# Altium schematic sheet Power.SchDoc(Power)
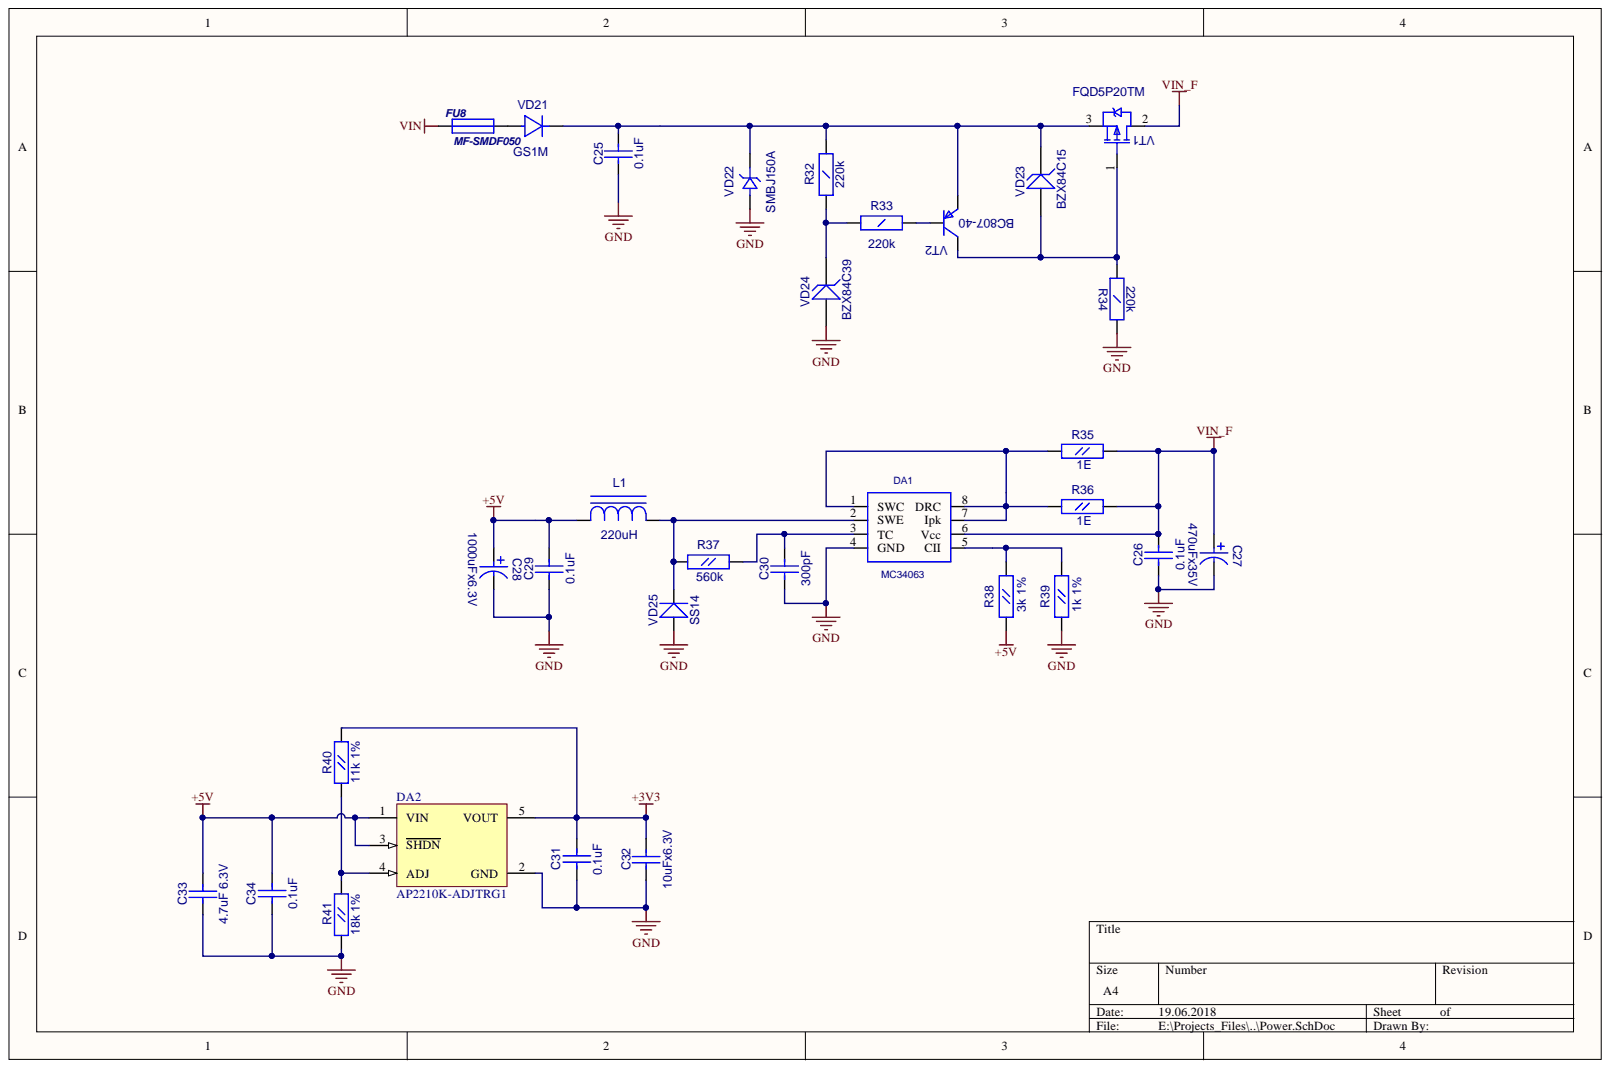
[diagram p0: Power.SchDoc]

## Parts
C25  0.1uF  [CC1206]
C26  0.1uF  [CC0603]
C27  470uFx35V  [CC16X10_CAP0.5(1)(Primary)]
C28  1000uFx6.3V  [CC8X3.5]
C29  0.1uF  [CC0603]
C30  300pF  [CC0603]
C31  0.1uF  [CC0603]
C32  10uFx6.3V  [CC0805]
C33  4.7uF 6.3V  [CC0805]
C34  0.1uF  [CC0603]
DA1  MC34063  [SO-8]
DA2  AP2210K-ADJTRG1  [SOT23-5]
FU8  MF-SMDF050  [MF-SMDF]
L1  220uH  [SDR0805]
R32  220k  [RC1206]
R33  220k  [RC1206]
R34  220k  [RC1206]
R35  1E  [RC0805]
R36  1E  [RC0805]
R37  560k  [RC0603]
R38  3k 1%  [RC0603]
R39  1k 1%  [RC0603]
R40  11k 1%  [RC0603]
R41  18k 1%  [RC0603]
VD21  GS1M  [SMA]
VD22  SMBJ150A  [SMB]
VD23  BZX84C15  [SOT-23(123)]
VD24  BZX84C39  [SOT-23(123)]
VD25  SS14  [SMA]
VT1  FQD5P20TM  [D-PAK(TO-252AA)]
VT2  BC807-40  [SOT-23(123)]

## Nets
+3V3: C31.1 C32.2 DA2.5(VOUT) R40.2
+5V: C28.1 C29.2 C33.2 C34.1 DA2.1(VIN) DA2.3(SHDN) L1.1 R38.1
GND: C25.1 C26.1 C27.1 C28.2 C29.1 C30.1 C31.2 C32.1 C33.1 C34.2 DA1.4(GND) DA2.2(GND) R34.2 R39.1 R41.1 VD22.1 VD24.1 VD25.1
VIN: FU8.1
VIN_F: C26.2 C27.2 DA1.6(Vcc) R35.2 R36.2 VT1.2
NetC25_2: C25.2 R32.2 VD21.2 VD22.2 VD23.3 VT1.3 VT2.2
NetC30_2: C30.2 DA1.3(TC) R37.2
NetDA1_1: DA1.1(SWC) DA1.7(Ipk) DA1.8(DRC) R35.1 R36.1
NetDA1_2: DA1.2(SWE) L1.2 R37.1 VD25.2
NetDA1_5: DA1.5(CII) R38.2 R39.2
NetDA2_4: DA2.4(ADJ) R40.1 R41.2
NetFU8_2: FU8.2 VD21.1
NetR32_1: R32.1 R33.1 VD24.3
NetR33_2: R33.2 VT2.1
NetR34_1: R34.1 VD23.1 VT1.1 VT2.3

## Library data of the parts
C25 = CC1206: Value: 0.1uF; Description: CC1206; Component Kind: Standard; Library Name: gprs_modem_2.SchLib
C26 C29-C31 C34 = CC0603: Description: CC0603; Component Kind: Standard; Library Name: gprs_modem_2.SchLib
  C26 C29 C31 C34: Value: 0.1uF
  C30: Value: 300pF
C27 = CAP0.5(1): Value: 470uFx35V; Description: CAP0.5(1); Component Kind: Standard; Library Name: gprs_modem_2.SchLib
C28 = CAP0.35: Value: 1000uFx6.3V; Description: CAP0.35; Component Kind: Standard; Library Name: gprs_modem_2.SchLib
C32 C33 = CC0805: Description: CC0805; Component Kind: Standard; Library Name: gprs_modem_2.SchLib
  C32: Value: 10uFx6.3V
  C33: Value: 4.7uF 6.3V
DA2 = MCP1824T-ADJE/OT: 300 mA, Low Voltage, Low Quiescent Current LDO Regulator, 5-Pin SOT-223, Extended Temperature, Tape and Reel; Published: 29-Jan-2009; PackageVersion: revAW, Oct-2008; PackageReference: SOT-223-DC5; PackageDescription: 5-Lead Plastic Small Outline Transistor (DC) [SOT-223]; DatasheetVersion: revA, Aug-2007; ComponentLink3URL: http://ww1.microchip.com/downloads/en/PackagingSpec/00049AW.pdf; ComponentLink3Description: Packaging Specification; ComponentLink2URL: http://ww1.microchip.com/downloads/en/DeviceDoc/22070a.pdf; ComponentLink2Description: Datasheet; ComponentLink1URL: http://www.microchip.com; ComponentLink1Description: Manufacturer Link; Component Kind: Standard; Publisher: Altium Limited; Library Name: gprs_modem_2.SchLib
FU8 = MF-SMDF: Value: MF-SMDF050; Description: MF-SMDF; Component Kind: Standard; Library Name: gprs_modem_2.SchLib
L1 = SDR0805: Value: 220uH; Description: SDR0805; Component Kind: Standard; Library Name: gprs_modem_2.SchLib
R32-R34 = RC1206: Value: 220k; Description: RC1206; Component Kind: Standard; Library Name: gprs_modem_2.SchLib
R35 R36 = RC0805: Value: 1E; Description: RC0805; Component Kind: Standard; Library Name: gprs_modem_2.SchLib
R37-R41 = RC0603: Description: RC0603; Component Kind: Standard; Library Name: gprs_modem_2.SchLib
  R37: Value: 560k
  R38: Value: 3k 1%
  R39: Value: 1k 1%
  R40: Value: 11k 1%
  R41: Value: 18k 1%
VD21 = GS1M: Value: {Value}; Description: GS1M; Component Kind: Standard; Library Name: gprs_modem.SchLib
VD22 = SMBJ: Value: SMBJ150A; Description: SMBJ; Component Kind: Standard; Library Name: gprs_modem_2.SchLib
VD23 VD24 = BZX84: Zener Voltage Regulator Diode; Value: {Value}; Component Kind: Standard; Library Name: gprs_modem_2.SchLib
VD25 = SS14: Schottky Rectifier; OriginalName: 10BQ100; ComponentField6: Published 8-Jun-2000, Modified 10-July-2000: SIM Model Added.; Value: SS14; Simulation: 10BQ100; Component Kind: Standard; Library Name: gprs_modem_2.SchLib
VT1 = IRFR9220: HEXFET Power MOSFET; OriginalName: IRLML5103; ComponentField7: Published 8-Jun-2000; Value: FQD5P20TM; Component Kind: Standard; Library Name: gprs_modem_2.SchLib
VT2 = BC857B: OriginalName: BC846BLT1; ComponentField7: Published 29-Sep-2000; Value: BC807-40; Simulation: BC846BLT1; Description: BC857B; Component Kind: Standard; Library Name: gprs_modem.SchLib
DA1 = MC34063: Description: MC34063; Component Kind: Standard; Library Name: Schetchik.SchLib
